# Altium schematic sheet Output.SchDoc
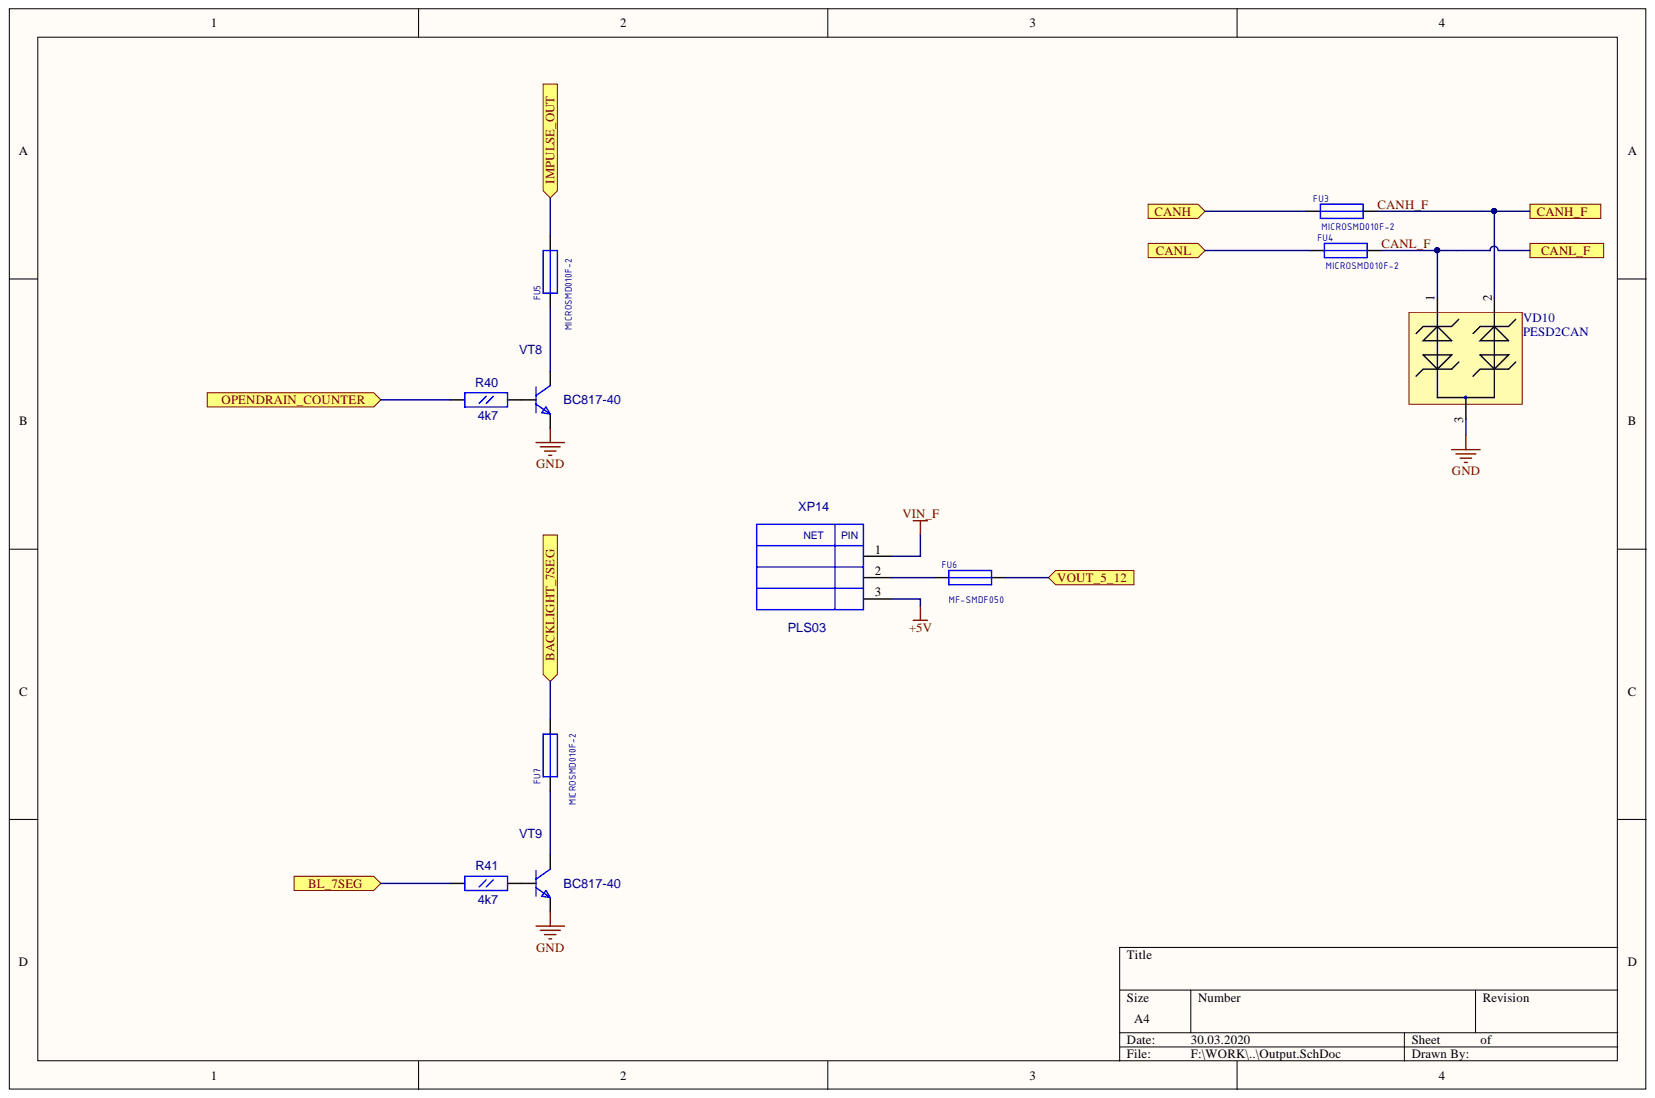
[diagram p0: Output.SchDoc]

## Parts
FU3  MICROSMD010F-2  [RC1210]
FU4  MICROSMD010F-2  [RC1210]
FU5  MICROSMD010F-2  [RC1210]
FU6  MF-SMDF050  [MF-SMDF]
FU7  MICROSMD010F-2  [RC1210]
R40  4k7  [RC0603]
R41  4k7  [RC0603]
VD10  PESD2CAN  [SOT-23(123)]
VT8  BC817-40  [SOT-23(123)]
VT9  BC817-40  [SOT-23(123)]
XP14  PLS03  [PLS-03]

## Ports
BACKLIGHT_7SEG, BL_7SEG, CANH, CANH_F, CANL, CANL_F, IMPULSE_OUT, OPENDRAIN_COUNTER, VOUT_5_12

## Nets
+5V: XP14.3
GND: VD10.3 VT8.2 VT9.2
VIN_F: XP14.1
BACKLIGHT_7SEG: FU7.2 ; port BACKLIGHT_7SEG
BL_7SEG: R41.1 ; port BL_7SEG
CANH: FU3.1 ; port CANH
CANH_F: FU3.2 VD10.2 ; port CANH_F
CANL: FU4.1 ; port CANL
CANL_F: FU4.2 VD10.1 ; port CANL_F
IMPULSE_OUT: FU5.2 ; port IMPULSE_OUT
OPENDRAIN_COUNTER: R40.1 ; port OPENDRAIN_COUNTER
VOUT_5_12: FU6.2 ; port VOUT_5_12
NetFU5_1: FU5.1 VT8.3
NetFU6_1: FU6.1 XP14.2
NetFU7_1: FU7.1 VT9.3
NetR40_2: R40.2 VT8.1
NetR41_2: R41.2 VT9.1

## Library data of the parts
FU3-FU5 FU7 = MICROSMD: Component Kind: Standard; Description: MICROSMD; Library Name: gprs_modem_2.SchLib
  FU3 FU4: Value: MICROSMD010F-2
FU6 = MF-SMDF: Component Kind: Standard; Description: MF-SMDF; Value: MF-SMDF050; Library Name: gprs_modem_2.SchLib
R40 R41 = RC0603: Component Kind: Standard; Description: RC0603; Value: 4k7; Library Name: gprs_modem_2.SchLib
VD10 = PESD2CAN: TVS for CAN; Component Kind: Standard; Library Name: gprs_modem_2.SchLib
VT8 VT9 = BC846BLT1: NPN 500ma transistor; ComponentField7: Published 29-Sep-2000; Component Kind: Standard; OriginalName: BC846BLT1; Simulation: BC846BLT1; Value: BC817-40; Library Name: gprs_modem_2.SchLib
XP14 = PLS03: Component Kind: Standard; Description: PLS03; Library Name: gprs_modem_2.SchLib
